# Altium schematic sheet diapers1.SchDoc
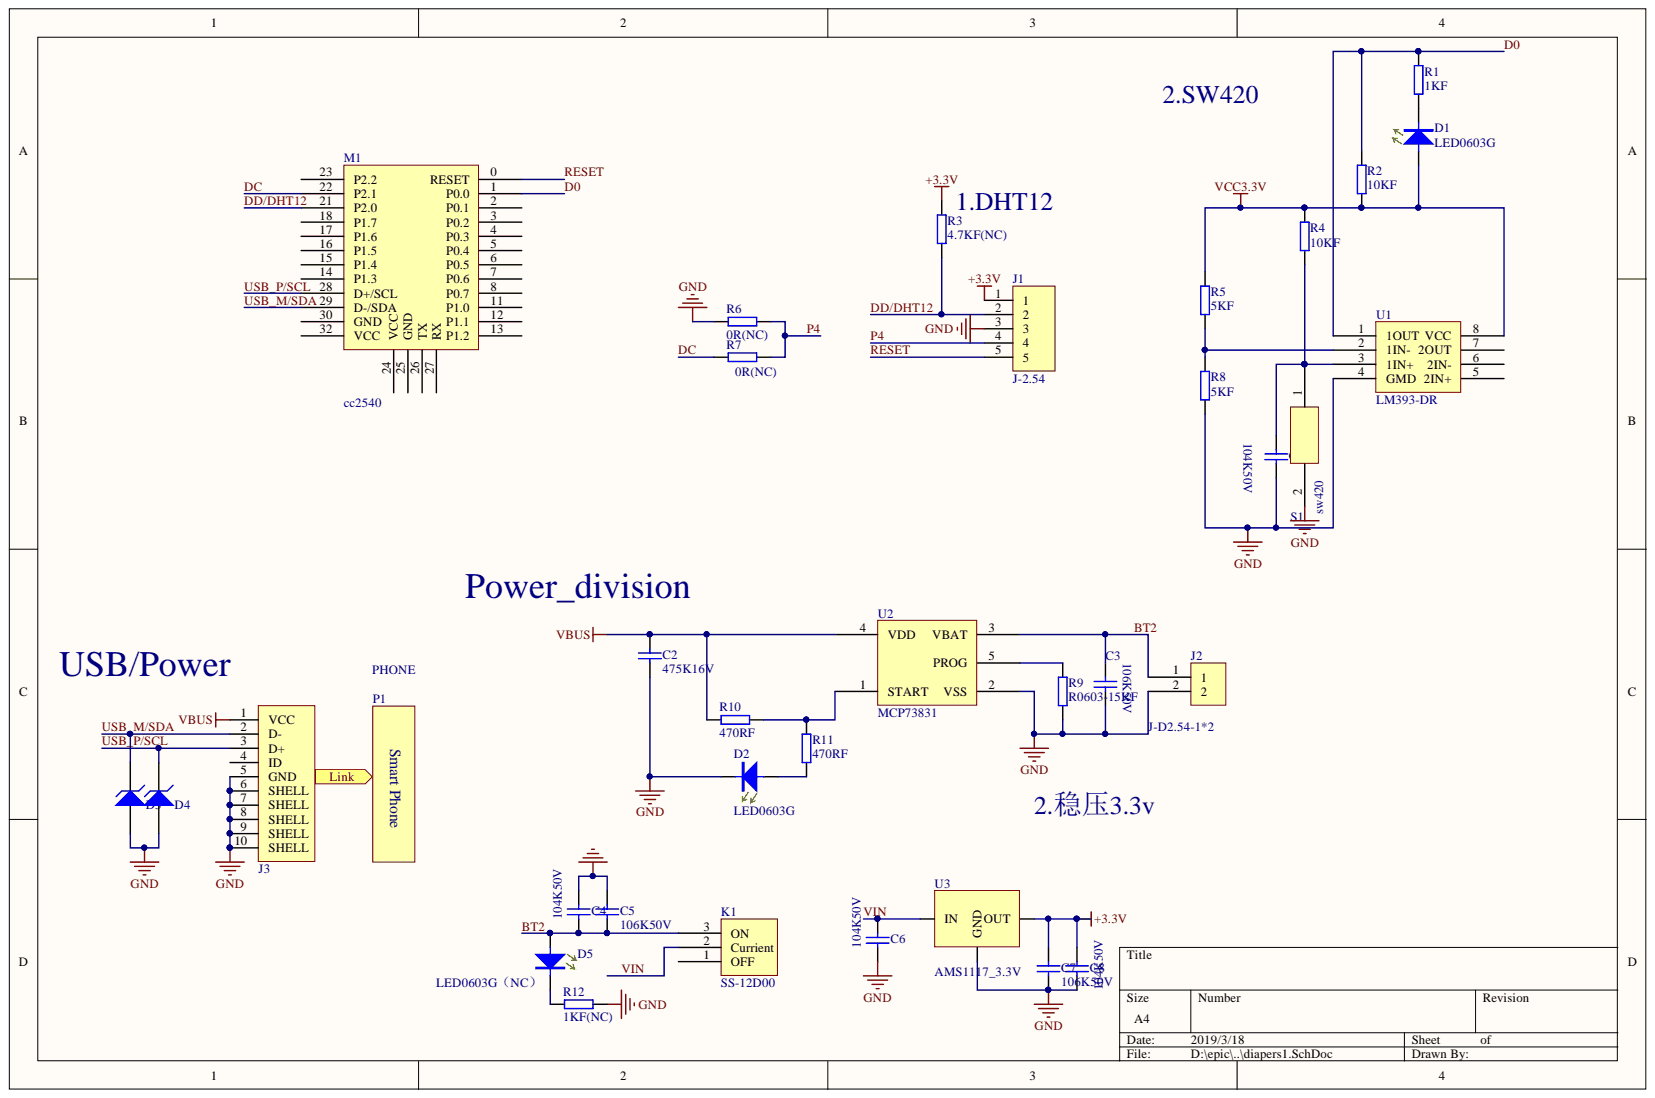
[diagram p0: diapers1.SchDoc]

## Parts
C1  104K50V  [C0603]
C2  475K16V  [C0603]
C3  106K50V  [C0603]
C4  104K50V  [C0603]
C5  106K50V  [C0603]
C6  104K50V  [C0603]
C7  106K50V  [C0603]
C8  104K50V  [C0603]
D1  LED0603G  [D-LED0603G]
D2  LED0603G  [D-LED0603G]
D3  ESDA_TR1/0603  [HS_0603D]  (value not drawn)
D4  ESDA_TR1/0603  [HS_0603D]  (value not drawn)
D5  LED0603G（NC）  [D-LED0603G]
J1  J-2.54  [D2.54-1*6]
J2  J-D2.54-1*2  [D2.54-1*2P]
J3  USB-MINI-SOCKET  [MICRO-USB - 5.9]  (value not drawn)
K1  SS-12D00  [SS-12D00]
M1  cc2540
P1  PHONE
R1  1KF  [R0603]
R2  10KF  [R0603]
R3  4.7KF(NC)  [R0603]
R4  10KF  [R0603]
R5  5KF  [R0603]
R6  0R(NC)  [R0402]
R7  0R(NC)  [R0402]
R8  5KF  [R0603]
R9  R0603-15KF  [R0603]
R10  470RF  [R0603]
R11  470RF  [R0603]
R12  1KF(NC)  [R0603]
S1  sw420  [sw420]
U1  LM393-DR  [LM393]
U2  MCP73831  [SOT23-5N]
U3  AMS1117_3.3V  [SOT-223]

## Ports
Link

## Nets
+3.3V: C7.2 C8.1 J1.1 R3.2 U3.2
GND: C1.2 C2.2 C3.2 C4.1 C5.2 C6.2 C7.1 C8.2 D2.2 D3.1 D4.1 J1.3 J2.2 J3.5(GND) J3.6(SHELL) J3.7(SHELL) J3.8(SHELL) J3.9(SHELL) J3.10(SHELL) R6.2 R8.1 R9.1 R12.2 U1.4(GMD) U2.2(VSS) U3.1
VBUS: C2.1 J3.1(VCC) R10.1 U2.4(VDD)
VCC3.3V: D1.1 R2.1 R4.2 R5.2 U1.8(VCC)
BT2: C3.1 C4.2 C5.1 D5.1 J2.1 K1.3 U2.3(VBAT)
D0: M1.1(P0.0) R1.1 R2.2 U1.1(1OUT)
DC: M1.22(P2.1) R7.1
DD/DHT12: J1.2 M1.21(P2.0) R3.1
P4: J1.4 R6.1 R7.2
RESET: J1.5 M1.0(RESET)
USB_M/SDA: D3.2 J3.2(D-) M1.29(D-/SDA)
USB_P/SCL: D4.2 J3.3(D+) M1.28(D+/SCL)
VIN: C6.1 K1.2 U3.3
NetC1_1: C1.1 R4.1 S1.1 U1.3(1IN+)
NetD1_2: D1.2 R1.2
NetD2_1: D2.1 R11.1
NetD5_2: D5.2 R12.1
NetR5_1: R5.1 R8.2 U1.2(1IN-)
NetR9_2: R9.2 U2.5(PROG)
NetR10_2: R10.2 R11.2 U2.1(START)
N00007: ; port Link

Not connected: J3 4(ID); K1 1; M1 2(P0.1) 3(P0.2) 4(P0.3) 5(P0.4) 6(P0.5) 7(P0.6) 8(P0.7) 11(P1.0) 12(P1.1) 13(P1.2) 14(P1.3) 15(P1.4) 16(P1.5) 17(P1.6) 18(P1.7) 23(P2.2) 24(VCC) 25(GND) 26(TX) 27(RX) 30(GND) 32(VCC); S1 2; U1 5(2IN+) 6(2IN-) 7(2OUT)

## Library data of the parts
C1 C4 C6 C8 = C0603X7R-104K50V: 贴片电容-陶瓷; 生产商: 风华 三星; 规格型号: 100nF±10%_50V_X7R_C0603; : 无编码 有封装; Component Kind: Standard; Library Name: Capacitor.SchLib
C2 = C0603X7R-475K16V: 贴片电容-陶瓷; 生产商: 风华 三星; 规格型号: 4.7uF±10%_16V_X7R_C0603; : 无编码有封装; Component Kind: Standard; Library Name: Capacitor.SchLib
C3 C5 C7 = C0603X7R-106K50V: 贴片电容-陶瓷; 生产商: 风华 三星; 规格型号: 10uF±10%_50V_X7R_C0603; : 无编码 有封装; Component Kind: Standard; Library Name: Capacitor.SchLib
D1 D2 D5 = DLED-0603-G: 贴片二极管-LED; 规格型号: 0603-GREEN; 货品编码: 无样品无编码; Component Kind: Standard
  D1 D2: Library Name: Diode.SchLib
  D5: Library Name: aiwacV2.0.SCHLIB
D3 D4 = D Zener: Zener Diode; Component Kind: Standard; Library Name: aiwacV2.0.SCHLIB
J1 = J-D2.54-1*5单排: J-2.54-5P; Component Kind: Standard; Library Name: Connector.SchLib
J2 = J-D2.54-1*2单排: Component Kind: Standard; Description: J-D2.54-1*2; Library Name: Connector.SchLib
J3 = J-USB-MINI-SOCKET: USB-A卧式插座; Component Kind: Standard; Library Name: epic2.SCHLIB
K1 = SS-12D00(1P2T) 立式 柄高5MM: Component Kind: Standard; Description: SS-12D00; Library Name: Key.SchLib
R1 R12 = R0603-1KF: 贴片电阻-通用; 生产商: 厚声 国巨; 规格型号: 1K±1%_1/10W_±200ppm_R0603; 货品编码: 无样品无编码; Component Kind: Standard; Library Name: Resistor.SchLib
R2 R4 R5 R8 = R0603-10KF: 贴片电阻-通用; 生产商: 厚声 国巨; 规格型号: 10K±1%_1/10W_±200ppm_R0603; Component Kind: Standard; Library Name: Resistor.SchLib
R3 = R0603-4.7KF: 贴片电阻-通用; 生产商: 厚声 国巨; 规格型号: 4.7K±1%_1/10W_±200ppm_R0603; 货品编码: 无样品无编码; Component Kind: Standard; Library Name: Resistor.SchLib
R6 R7 = R0402-0RF: 贴片电阻-通用; 生产商: 厚声 国巨; 规格型号: 0R_1/10W_±200ppm_R0402; 货品编码: 无样品无编码; Component Kind: Standard; Library Name: Resistor.SchLib
R9 = R0603-15KF: 贴片电容-通用; Component Kind: Standard; Library Name: Resistor.SchLib
R10 R11 = R0603-470RF: 贴片电阻-通用; 生产商: 厚声 国巨; 规格型号: 470R±1%_1/10W_±200ppm_R0603; 货品编码: 无样品无编码; Component Kind: Standard; Library Name: Resistor.SchLib
S1 = sw420: 常闭振动传感器; Component Kind: Standard; Library Name: sensor.SchLib
U1 = LM393-DR: 双路比较器; Component Kind: Standard; Library Name: IC.SchLib
U2 = MCP73831: charge IC; Component Kind: Standard; Library Name: IC.SchLib
U3 = AMS1117-3.3V: Component Kind: Standard; Description: AMS1117_3.3V; Library Name: IC.SchLib
M1 = cc2540: Component Kind: Standard; Library Name: diapers1.SchLib
P1 = PHONE: Component Kind: Standard; Library Name: epic.SchLib
